# Altium schematic sheet FuzzBuddySchematic.SchDoc
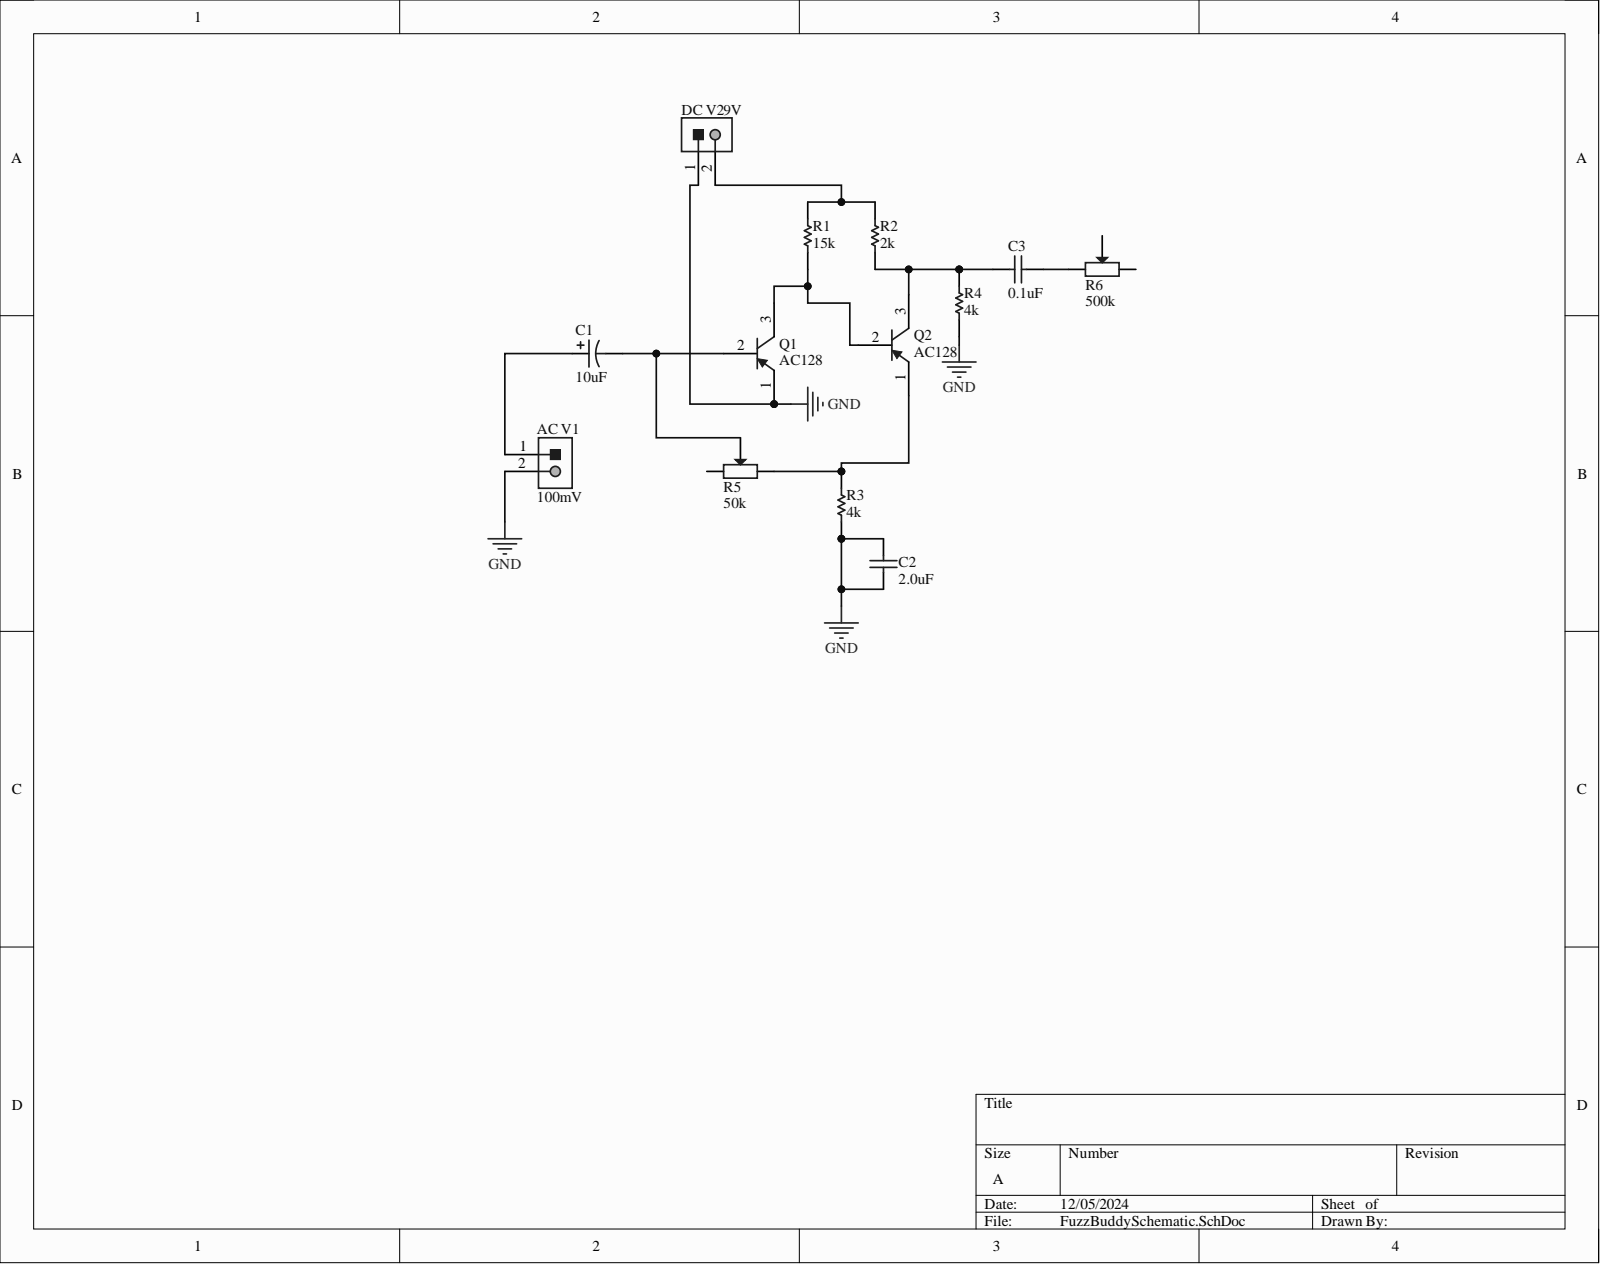
[diagram p0: FuzzBuddySchematic.SchDoc]

## Parts
AC_V1  100mV  [PCB-l75efs6xaz434828amam-1]
C1  10uF  [Capacitor]
C2  2.0uF  [PCB-g3bvlu0jlfi4ixgmi7xa-1]
C3  0.1uF  [PCB-rw1ov33dmkysl1xmhhnh-1]
DC_V2  9V  [PCB-l75efs6xaz434828amam-1]  (value not drawn)
Q1  AC128  [PCB-dqc7n9yg9dhx7f2vwmox-1]
Q2  AC128  [PCB-dqc7n9yg9dhx7f2vwmox-1]
R1  15k  [PCB-koi6djzl8q2j18xgqp8k-1]
R2  2k  [PCB-koi6djzl8q2j18xgqp8k-1]
R3  4k  [PCB-koi6djzl8q2j18xgqp8k-1]
R4  4k  [PCB-koi6djzl8q2j18xgqp8k-1]
R5  50k  [PCB-xl0q21yz4e014qn7jzjj-1]
R6  500k  [PCB-xl0q21yz4e014qn7jzjj-1]

## Nets
GND: AC_V1.2 C2.1 C2.2 DC_V2.1 Q1.1 R3.1 R4.1
NetAC V1_1: AC_V1.1 C1.1
NetC1_2: C1.2 Q1.2 R5.3
NetC3_1: C3.1 Q2.3 R2.1 R4.2
NetC3_2: C3.2 R6.1
NetDC V2_2: DC_V2.2 R1.2 R2.2
NetQ1_3: Q1.3 Q2.2 R1.1
NetQ2_1: Q2.1 R3.2 R5.2

Not connected: R5 1; R6 2 3

## Library data of the parts
AC_V1 DC_V2 = CMP-b1307a6381eb6ef7-7: Conn Wire to Board HDR 2 POS 3.96mm Solder ST Thru-Hole Automotive Bag; Manufacturer Part Number: 1-1123723-2; ELV Compliant: Compliant; Gender: Male; Lead-Free Status: Lead Free; Mounting Angle: 180 °; Number of Contacts: 2; Color: Natural; Component Kind: Standard; Contact Material: Brass; Contact Plating: Tin; Flammability Rating: UL 94 V-0; Housing Color: Natural; Housing Material: Nylon; Library Name: My Personal Space; Lifecycle Status: Active; Material: Nylon; Mounting Style: Through Hole; Number of Positions: 2; Number of Rows: 1; Octopart URL: https://octopart.com/1-1123723-2-te+connectivity-39392701; OctopartID: b1307a6381eb6ef7; Operating Temperature: -25.0 °C to 105 °C; Orientation: Vertical; Packaging: Bag; Pin Pitch: 3.96 mm; RoHS: Compliant; Size-Height: 3.00 mm; Size-Length: 7.86 mm; Size-Width: 8.50 mm
C1 = CMP-246eec3ca4ccfba9-1: In a Pack of 2, Rubycon 470µF Electrolytic Capacitor 63V dc Through Hole - 63YXJ470M12.5X25; Capacitance Tolerance: ±20%; Lead-Free Status: Lead Free; Voltage Rating (DC): 63.0 V; Capacitance: 470 µF; Component Kind: Standard; Library Name: My Personal Space; Manufacturer Part Number: 63YXJ470M12.5X25; Mounting Style: Radial, Through Hole; Octopart Url: https://octopart.com/63yxj470m12.5x25-rubycon-22586820; OctopartID: 246eec3ca4ccfba9; Operating Temperature: 105 °C (max); REACH SVHC Compliance: No SVHC; RoHS: Compliant; Size-Diameter: 12.5 mm; Size-Height: 25.0 mm
C2 = CMP-5b519235aabf8496-1: Epcos B32654 1uF Polypropylene Film Capacitor 250V ac; 630VDC +/-10%; Thru Hole; Manufacturer Part Number: B32654A6105K; Capacitance: 1.00 µF; Capacitance Tolerance: ±10%; Voltage Rating (DC): 630 V; Component Kind: Standard; Dielectric Material: Polypropylene; Library Name: My Personal Space; Mounting Style: Through Hole; Octopart URL: https://octopart.com/b32654a6105k-epcos-7263368; OctopartID: 5b519235aabf8496; Packaging: Bulk; RoHS: Compliant
C3 = CMP-6eefa6f3f1c8b128-3: Ceramic Disc & Plate Capacitor, F Series, 150 pF, 10%, Y5R, 2 kV, Radial Leaded; Component Kind: Standard; Library Name: My Personal Space
Q1 Q2 = CMP-fba333da8e182464-2: Germanium PNP transistor, AC128 1A; Component Kind: Standard; Library Name: My Personal Space; Manufacturer Part Number: AC128; Mounting Style: Through Hole; Octopart URL: http://octopart.com/ac128-magnatec-24334557; OctopartID: fba333da8e182464; RoHS: Non-Compliant
R1-R4 = CMP-e0bd2886b5da3f7a-1: 5000; Manufacturer Part Number: MF0207FTE52-620K; Component Kind: Standard; Library Name: My Personal Space; Octopart URL: http://octopart.com/mf0207fte52-620k-yageo-20017153; OctopartID: e0bd2886b5da3f7a
R5 R6 = CMP-2ce0e4db07def327-1: Rotary Potentiometer, 17mm, Knurled Shaft; Manufacturer Part Number: PDB181-GTR01-504A2; Power Rating: 100 mW; Resistance Tolerance: ±20%; Voltage Rating (DC): 150 V; Component Kind: Standard; Library Name: My Personal Space; Octopart URL: http://octopart.com/pdb181-gtr01-504a2-bourns-19992152; OctopartID: 2ce0e4db07def327; RoHS: Compliant
